# Altium schematic sheet [09] - COMMUNICATION.SchDoc("[09] - COMMUNICATION")
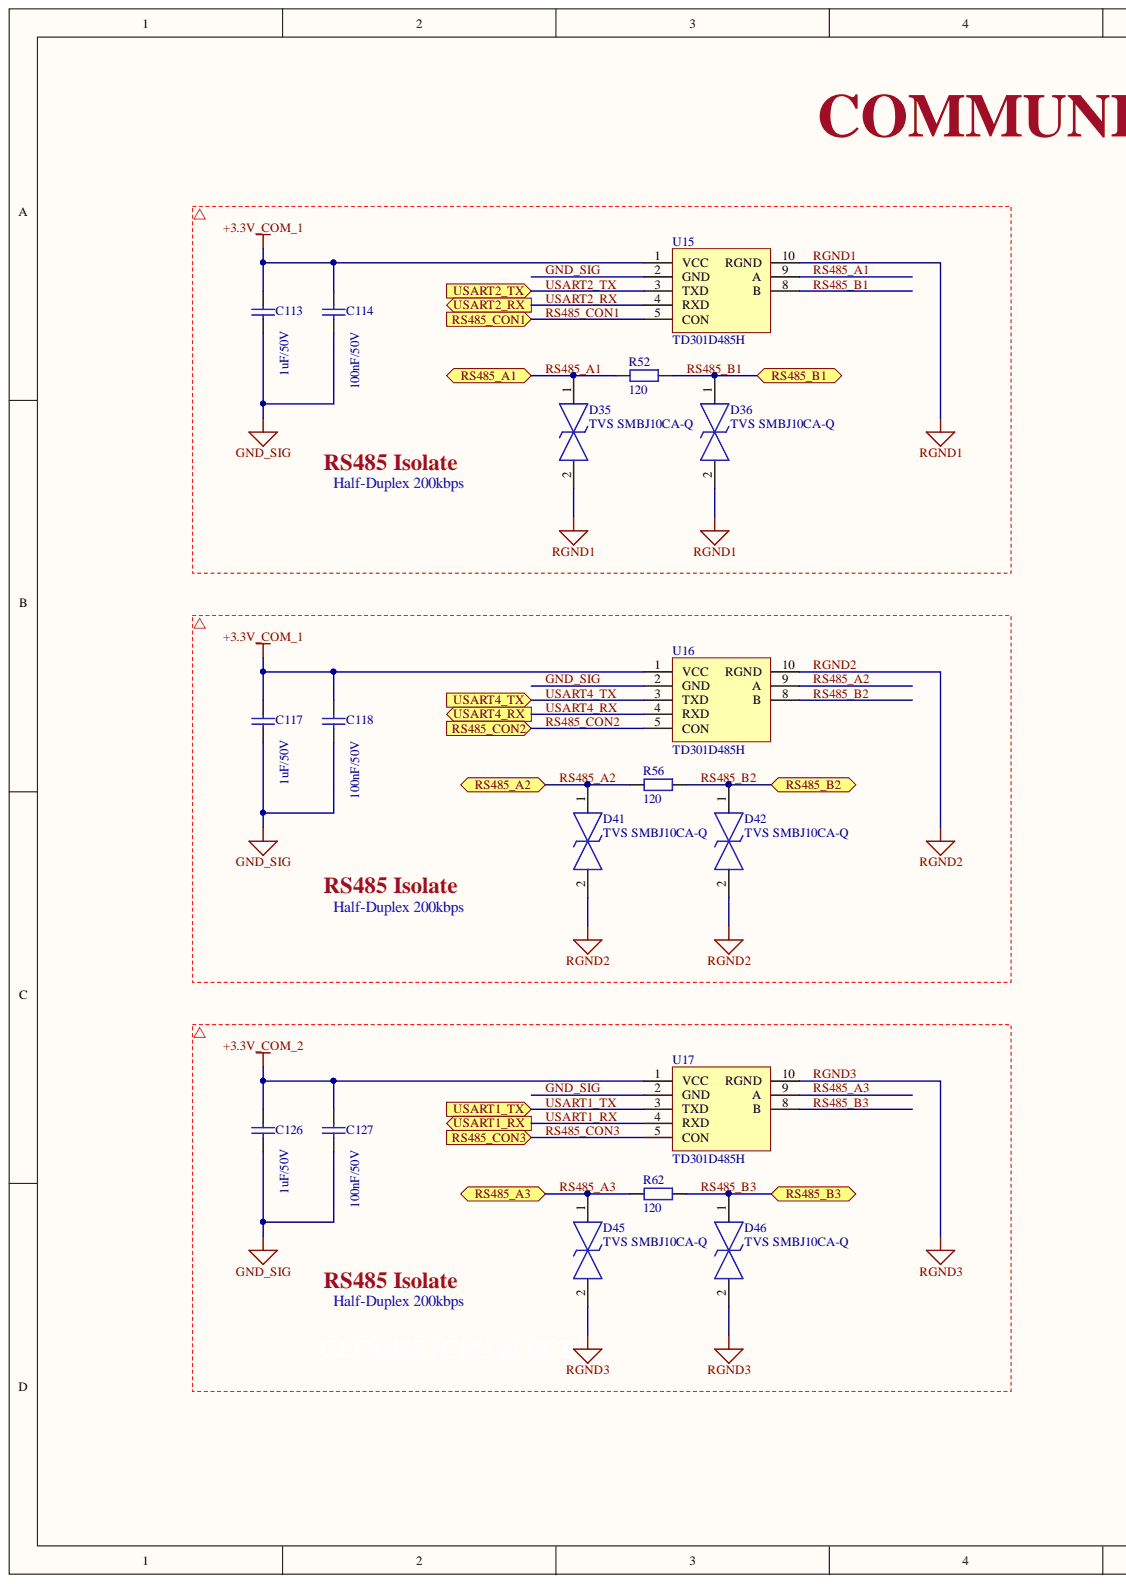
[diagram p0: [09] - COMMUNICATION.SchDoc, left]
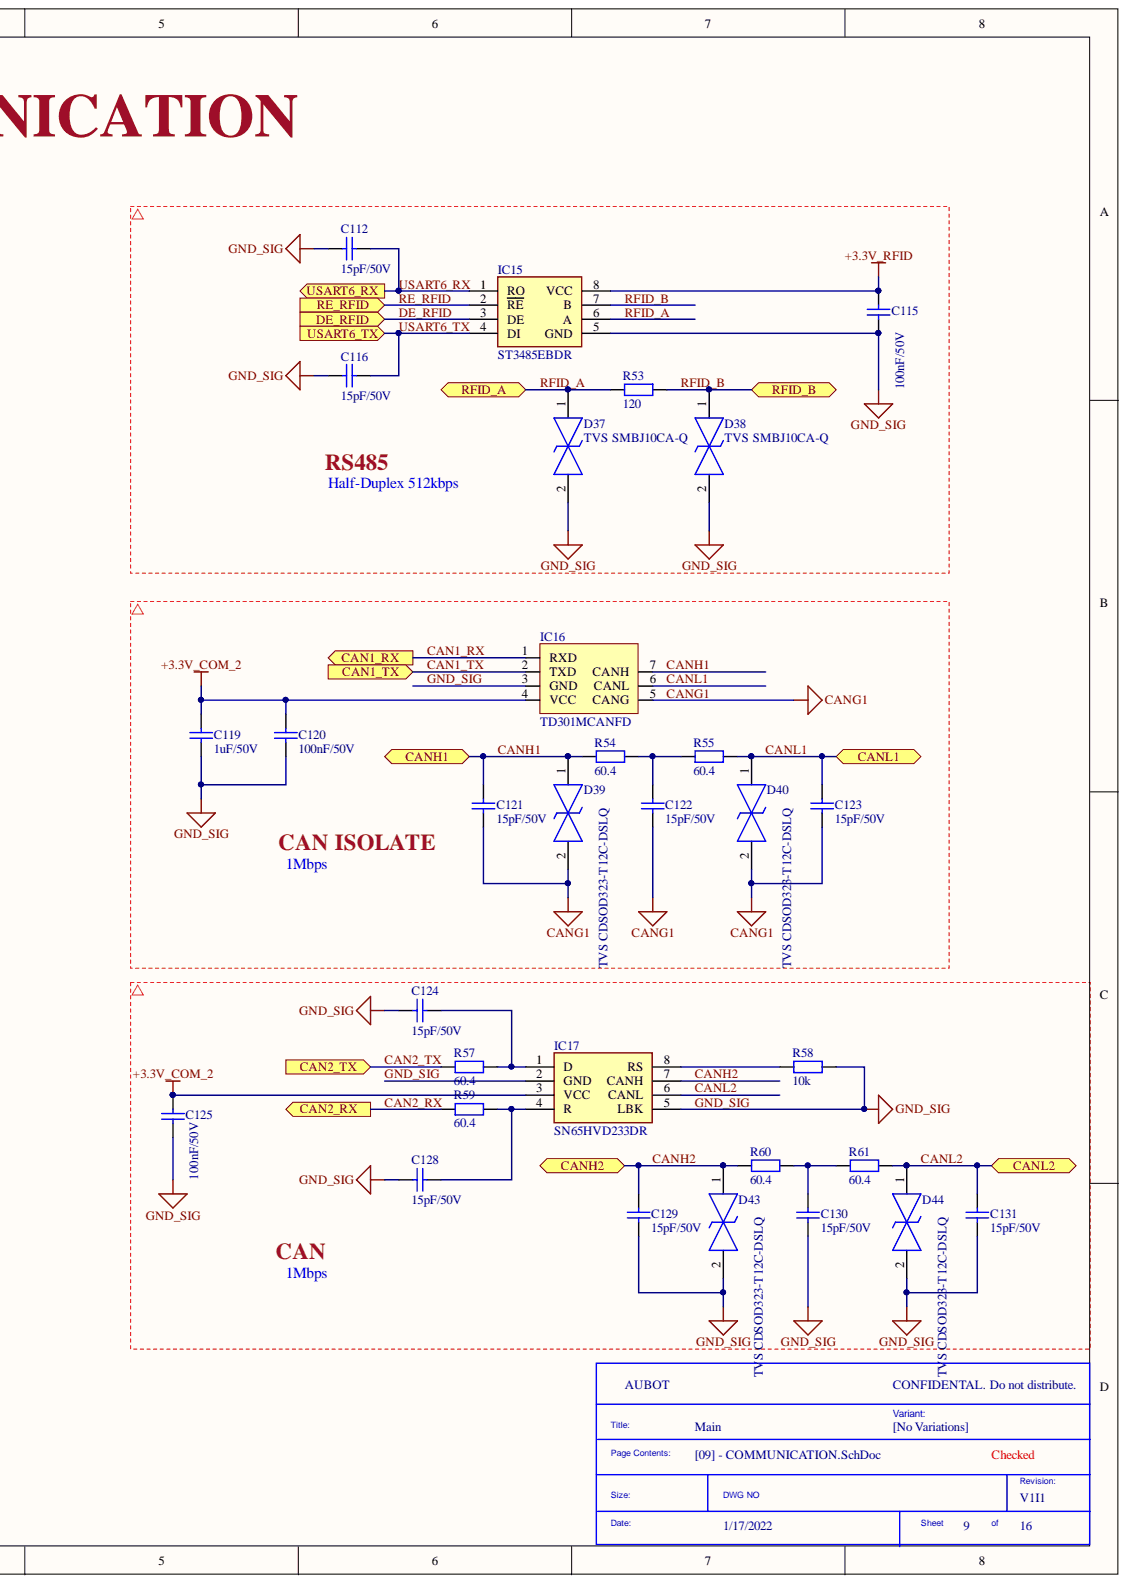
[diagram p1: [09] - COMMUNICATION.SchDoc, right]

## Parts
C112  15pF/50V  [CAP 0805]
C113  1uF/50V  [CAP 0805]
C114  100nF/50V  [CAP 0805]
C115  100nF/50V  [CAP 0805]
C116  15pF/50V  [CAP 0805]
C117  1uF/50V  [CAP 0805]
C118  100nF/50V  [CAP 0805]
C119  1uF/50V  [CAP 0805]
C120  100nF/50V  [CAP 0805]
C121  15pF/50V  [CAP 0805]
C122  15pF/50V  [CAP 0805]
C123  15pF/50V  [CAP 0805]
C124  15pF/50V  [CAP 0805]
C125  100nF/50V  [CAP 0805]
C126  1uF/50V  [CAP 0805]
C127  100nF/50V  [CAP 0805]
C128  15pF/50V  [CAP 0805]
C129  15pF/50V  [CAP 0805]
C130  15pF/50V  [CAP 0805]
C131  15pF/50V  [CAP 0805]
D35  TVS SMBJ10CA-Q  Manufacturer Part Number: SMBJ10CA-Q  [TVS SMBJ]
D36  TVS SMBJ10CA-Q  Manufacturer Part Number: SMBJ10CA-Q  [TVS SMBJ]
D37  TVS SMBJ10CA-Q  Manufacturer Part Number: SMBJ10CA-Q  [TVS SMBJ]
D38  TVS SMBJ10CA-Q  Manufacturer Part Number: SMBJ10CA-Q  [TVS SMBJ]
D39  TVS CDSOD323-T12C-DSLQ  Manufacturer Part Number: CDSOD323-T12C-DSLQ  [TVS SOD-323-2]
D40  TVS CDSOD323-T12C-DSLQ  Manufacturer Part Number: CDSOD323-T12C-DSLQ  [TVS SOD-323-2]
D41  TVS SMBJ10CA-Q  Manufacturer Part Number: SMBJ10CA-Q  [TVS SMBJ]
D42  TVS SMBJ10CA-Q  Manufacturer Part Number: SMBJ10CA-Q  [TVS SMBJ]
D43  TVS CDSOD323-T12C-DSLQ  Manufacturer Part Number: CDSOD323-T12C-DSLQ  [TVS SOD-323-2]
D44  TVS CDSOD323-T12C-DSLQ  Manufacturer Part Number: CDSOD323-T12C-DSLQ  [TVS SOD-323-2]
D45  TVS SMBJ10CA-Q  Manufacturer Part Number: SMBJ10CA-Q  [TVS SMBJ]
D46  TVS SMBJ10CA-Q  Manufacturer Part Number: SMBJ10CA-Q  [TVS SMBJ]
IC15  ST3485EBDR  [SOIC-8]
IC16  TD301MCANFD  [TD301MCANFD]
IC17  SN65HVD233DR  Case/Package: D  [SOIC-8]
R52  120  [RES 0805]
R53  120  [RES 0805]
R54  60.4  [RES 0805]
R55  60.4  [RES 0805]
R56  120  [RES 0805]
R57  60.4  [RES 0805]
R58  10k  [RES 0805]
R59  60.4  [RES 0805]
R60  60.4  [RES 0805]
R61  60.4  [RES 0805]
R62  120  [RES 0805]
U15  TD301D485H  [TD301D485H]
U16  TD301D485H  [TD301D485H]
U17  TD301D485H  [TD301D485H]

## Ports
CAN1_RX, CAN1_TX, CAN2_RX, CAN2_TX, CANH1, CANH2, CANL1, CANL2, DE_RFID, RE_RFID, RFID_A, RFID_B, RS485_A1, RS485_A2, RS485_A3, RS485_B1, RS485_B2, RS485_B3, RS485_CON1, RS485_CON2, RS485_CON3, USART1_RX, USART1_TX, USART2_RX, USART2_TX, USART4_RX, USART4_TX, USART6_RX, USART6_TX

## Nets
+3.3V_COM_1: C113.1 C114.2 C117.1 C118.2 U15.1(VCC) U16.1(VCC)
+3.3V_COM_2: C119.2 C120.1 C125.2 C126.1 C127.2 IC16.4(VCC) IC17.3(VCC) U17.1(VCC)
+3.3V_RFID: C115.1 IC15.8(VCC)
CAN1_RX: IC16.1(RXD) ; port CAN1_RX
CAN1_TX: IC16.2(TXD) ; port CAN1_TX
CAN2_RX: R59.1 ; port CAN2_RX
CAN2_TX: R57.1 ; port CAN2_TX
CANG1: C121.1 C122.1 C123.1 D39.2 D40.2 IC16.5(CANG)
CANH1: C121.2 D39.1 IC16.7(CANH) R54.1 ; port CANH1
CANH2: C129.2 D43.1 IC17.7(CANH) R60.1 ; port CANH2
CANL1: C123.2 D40.1 IC16.6(CANL) R55.2 ; port CANL1
CANL2: C131.2 D44.1 IC17.6(CANL) R61.2 ; port CANL2
DE_RFID: IC15.3(DE) ; port DE_RFID
GND_SIG: C112.1 C113.2 C114.1 C115.2 C116.1 C117.2 C118.1 C119.1 C120.2 C124.1 C125.1 C126.2 C127.1 C128.1 C129.1 C130.1 C131.1 D37.2 D38.2 D43.2 D44.2 IC15.5(GND) IC16.3(GND) IC17.2(GND) IC17.5(LBK) R58.2 U15.2(GND) U16.2(GND) U17.2(GND)
RE_RFID: IC15.2(RE) ; port RE_RFID
RFID_A: D37.1 IC15.6(A) R53.2 ; port RFID_A
RFID_B: D38.1 IC15.7(B) R53.1 ; port RFID_B
RGND1: D35.2 D36.2 U15.10(RGND)
RGND2: D41.2 D42.2 U16.10(RGND)
RGND3: D45.2 D46.2 U17.10(RGND)
RS485_A1: D35.1 R52.2 U15.9(A) ; port RS485_A1
RS485_A2: D41.1 R56.2 U16.9(A) ; port RS485_A2
RS485_A3: D45.1 R62.2 U17.9(A) ; port RS485_A3
RS485_B1: D36.1 R52.1 U15.8(B) ; port RS485_B1
RS485_B2: D42.1 R56.1 U16.8(B) ; port RS485_B2
RS485_B3: D46.1 R62.1 U17.8(B) ; port RS485_B3
RS485_CON1: U15.5(CON) ; port RS485_CON1
RS485_CON2: U16.5(CON) ; port RS485_CON2
RS485_CON3: U17.5(CON) ; port RS485_CON3
USART1_RX: U17.4(RXD) ; port USART1_RX
USART1_TX: U17.3(TXD) ; port USART1_TX
USART2_RX: U15.4(RXD) ; port USART2_RX
USART2_TX: U15.3(TXD) ; port USART2_TX
USART4_RX: U16.4(RXD) ; port USART4_RX
USART4_TX: U16.3(TXD) ; port USART4_TX
USART6_RX: C112.2 IC15.1(RO) ; port USART6_RX
USART6_TX: C116.2 IC15.4(DI) ; port USART6_TX
NetC122_2: C122.2 R54.2 R55.1
NetC124_2: C124.2 IC17.1(D) R57.2
NetC128_2: C128.2 IC17.4(R) R59.2
NetC130_2: C130.2 R60.2 R61.1
NetIC17_8: IC17.8(RS) R58.1

## Library data of the parts
C112 C116 C121-C124 C128-C131 = Cap 0805 15pF/50V: Cap, AEC-Q200, 15PF, 50V, Mlcc, 0805;; Manufacturer Part Number: C0805C150K5RACAUTO; Manufacturer: KEMET; Supplier Part Number 1: 80-C0805C150K5RAUTO; Supplier 1: Mouser; Stock 1: 14030; RoHS Compliant: Yes; RoHS: Yes; Pricing 1: 1 = 0.31000, 10 = 0.20400, 50 = 0.20400, 100 = 0.10400, 1000 = 0.07000, 10000 = 0.06000 (USD); Component Kind: Standard; Library Name: Hieu_Lib.IntLib
C113 C117 C119 C126 = Cap 0805 1uF/50V: 0805 1 uF 50 V ±10 % Tolerance X7R SMT Multilayer Ceramic Capacitor; Manufacturer Part Number: CC0805KKX7R9BB105; Manufacturer: Yageo; Voltage Rating (DC): 50V; Tolerance: 10%; Capacitance: 1uF; Width: 0.049inch; Weight: 0.000194oz; Voltage Rating: 50V; Thickness: 0.057inch; Termination: SMD/SMT; Supplier Part Number 1: 311-1886-1-ND; Supplier 1: Digi-Key; Stock 1: 174238; Series: CC; RoHS Compliant: Yes; RoHS: Yes; Resistor Type: Ceramic; Radiation Hardening: No; Pricing 1: 1 = 0.26000, 10 = 0.17900, 50 = 0.11920, 100 = 0.10130, 500 = 0.07152, 1000 = 0.06257 (USD); Packaging: Tape and Reel; Mount: Surface Mount; Min Operating Temperature: -55°C; Max Operating Temperature: 125°C; Length: 2mm; Lead Free: Lead Free; Height: 1.25mm; Halogen Free: Halogen Free; Dielectric: X7R; Depth: 1.25mm; Construction: Flat; ComponentLink1URL: https://datasheet.ciiva.com/13209/upy-gphc-x7r-6-3v-to-50v-8-12304-13209375.pdf?src-supplier=Mouser; ComponentLink1Description: https://datasheet.ciiva.com/13209/upy-gphc-x7r-6-3v-to-50v-8-12304-13209375.pdf?src-supplier=Mouser; Component Kind: Standard; Case Code (Metric): 2012; Case Code (Imperial): 0805; Case/Package: 0805; Library Name: Hieu_Lib.IntLib
C114 C115 C118 C120 C125 C127 = Cap 0805 100nF/50V: Ceramic capacitor SMD-0805 100nF /50V X7R# K +-10%, Samsung, RoHS; Manufacturer Part Number: CL21B104KBCNNNC; Voltage Rating (DC): 50V; Tolerance: 10%; Dielectric: X7R; Width: 0.049inch; Voltage Rating: 50V; Voltage: 50V; Thickness: 0.037inch; Supplier Part Number 1: C1711; Supplier 1: LCSC; Stock 1: 447650; Series: CL; RoHS Compliant: Yes; RoHS: Yes; Radiation Hardening: No; Pricing 1: 50 = 0.04090, 500 = 0.03130, 1500 = 0.02890, 5000 = 0.02580, 25000 = 0.02430, 50000 = 0.02410, 50 = 0.03950, 500 = 0.03020, 1500 = 0.02790, 5000 = 0.02490, 25000 = 0.02350, 50000 = 0.02330, 50 = 0.20680, 500 = 0.15820, 1500 = 0.14600, 5000 = 0.13020, 25000 = 0.12290, 50000 = 0.12170, 50 = 0.19640, 500 = 0.15020, 1500 = 0.13860, 5000 = 0.12360, 25000 = 0.11670, 50000 = 0.11550, 50 = 0.02640, 500 = 0.02020, 1500 = 0.01860, 5000 = 0.01660, 25000 = 0.01570, 50000 = 0.01550, 50 = 0.02300, 500 = 0.01760, 1500 = 0.01620, 5000 = 0.01440, 25000 = 0.01360, 50000 = 0.01350, 50 = 0.24740, 500 = 0.18920, 1500 = 0.17470, 5000 = 0.15570, 25000 = 0.14700, 50000 = 0.14560, 50 = 3.45220, 500 = 2.64000, 1500 = 2.43700, 5000 = 2.17300, 25000 = 2.05110, 50000 = 2.03080, 50 = 0.13070, 500 = 0.09990, 1500 = 0.09220, 5000 = 0.08230, 25000 = 0.07760, 50000 = 0.07690, 50 = 2.39050, 500 = 1.82810, 1500 = 1.68750, 5000 = 1.50470, 25000 = 1.42030, 50000 = 1.40620, 50 = 0.26740, 500 = 0.20450, 1500 = 0.18870, 5000 = 0.16830, 25000 = 0.15890, 50000 = 0.15730, 50 = 0.04230, 500 = 0.03230, 1500 = 0.02990, 5000 = 0.02660, 25000 = 0.02510, 50000 = 0.02490, 50 = 0.03188, 500 = 0.02438, 1500 = 0.02251, 5000 = 0.02007, 25000 = 0.01894, 50000 = 0.01875 (AUD); Packaging: Tape and Reel; Mount: Surface Mount; Min Operating Temperature: -55°C; Max Operating Temperature: 125°C; Material: Ceramic; Manufacturer: Samsung; Length: 2mm; Lead Free: Lead Free; Height: 0.85mm; Depth: 1.25mm; Contact Plating: Tin; Construction: Flat; ComponentLink2URL: https://datasheet.ciiva.com/29199/454152-da-01-en-vs-kondensator-0805-29199814.pdf?src-supplier=Conrad; ComponentLink2Description: https://datasheet.ciiva.com/29199/454152-da-01-en-vs-kondensator-0805-29199814.pdf?src-supplier=Conrad; ComponentLink1URL: https://datasheet.ciiva.com/25710/cl21b104kbcnnnc-25710182.pdf?src-supplier=Digi-Key; ComponentLink1Description: https://datasheet.ciiva.com/25710/cl21b104kbcnnnc-25710182.pdf?src-supplier=Digi-Key; Component Kind: Standard; Case Code (Metric): 2012; Case Code (Imperial): 0805; Case/Package: 0805; Capacitance: 100nF; Library Name: Hieu_Lib.IntLib
D35-D38 D41 D42 D45 D46 = TVS SMBJ10CA-Q: ESD Suppressors / TVS Diodes DIO TVS VRWM 10V 600W BIDIR SMB AECQ; Manufacturer: Bourns; Supplier Part Number 1: 652-SMBJ10CA-Q; Supplier 1: Mouser; Stock 1: 0; RoHS: No; Pricing 1: 1 = 0.43000, 10 = 0.37200, 50 = 0.37200, 100 = 0.27800, 1000 = 0.16900, 10000 = 0.15300 (USD); Component Kind: Standard; Library Name: Hieu_Lib.SchLib
D39 D40 D43 D44 = TVS CDSOD323-T12C-DSLQ: ESD Suppressor Diode TVS Bi-Dir 12V Automotive 2-Pin SOD-323 T/R; Manufacturer: Bourns; Supplier Part Number 1: 652-CDSOD323T12CDSLQ; Supplier 1: Mouser; Stock 1: 8414; RoHS Compliant: Yes; RoHS: Yes; Pricing 1: 1 = 0.82000, 10 = 0.72600, 50 = 0.72600, 100 = 0.55700, 1000 = 0.35200, 10000 = 0.27900 (USD); ComponentLink1URL: https://www.bourns.com/docs/Product-Datasheets/CDSOD323-T12C-DSLQ.pdf?src-supplier=Digi-Key; ComponentLink1Description: https://www.bourns.com/docs/Product-Datasheets/CDSOD323-T12C-DSLQ.pdf?src-supplier=Digi-Key; Component Kind: Standard; Library Name: Hieu_Lib.IntLib
IC15 = ST3485EBDR: ST3485E Series 3.3 V ±15 kV ESD Protected RS-485/RS-422 Transceiver - SOIC-8; Manufacturer: STMicroelectronics; Operating Supply Voltage: 3.3V; Number of Transmitters: 1; Nominal Supply Current: 2.2mA; Min Supply Voltage: 3V; Max Supply Voltage: 3.6V; Interface: RS-422,RS-485; ESD Protection: Yes; Data Rate: 15Mbps; Width: 4mm; Supplier Part Number 1: 497-2080-6-ND; Supplier 1: Digi-Key; Stock 1: 0; RoHS: Yes; REACH SVHC: No SVHC; Radiation Hardening: No; Pricing 1: 1 = 2.18000, 10 = 1.96200, 25 = 1.85120, 100 = 1.57710, 250 = 1.48080, 500 = 1.29572, 1000 = 1.22238 (USD); Packaging: Tape and Reel; Package Quantity: 1; Number of Transceivers: 1; Number of Receivers: 1; Number of Pins: 8; Number of Drivers: 1; Mount: Surface Mount; Min Operating Temperature: -40°C; Max Supply Current: 2.2mA; Max Operating Temperature: 85°C; Manufacturer Part Number: ST3485EBDR; Logic Function: Transceiver; Length: 5mm; Lead Free: Lead Free; Height: 1.25mm; Contact Plating: Gold; ComponentLink6URL: https://datasheet.ciiva.com/7630/1788494-7630124.pdf?src-supplier=Element14; ComponentLink6Description: https://datasheet.ciiva.com/7630/1788494-7630124.pdf?src-supplier=Element14; ComponentLink5URL: https://datasheet.ciiva.com/7630/1788494-7630107.pdf?src-supplier=Element14; ComponentLink5Description: https://datasheet.ciiva.com/7630/1788494-7630107.pdf?src-supplier=Element14; ComponentLink4URL: https://datasheet.ciiva.com/16209/cd00003137-16209866.pdf?src-supplier=Future+Electronics; ComponentLink4Description: https://datasheet.ciiva.com/16209/cd00003137-16209866.pdf?src-supplier=Future+Electronics; ComponentLink3URL: https://datasheet.ciiva.com/1703/cd00003137-1703123.pdf?src-supplier=Digi-Key; ComponentLink3Description: https://datasheet.ciiva.com/1703/cd00003137-1703123.pdf?src-supplier=Digi-Key; ComponentLink2URL: https://datasheet.ciiva.com/1703/cd00003137-1703122.pdf?src-supplier=Digi-Key; ComponentLink2Description: https://datasheet.ciiva.com/1703/cd00003137-1703122.pdf?src-supplier=Digi-Key; ComponentLink1URL: https://datasheet.ciiva.com/1703/cd00003137-1703121.pdf?src-supplier=Digi-Key; ComponentLink1Description: https://datasheet.ciiva.com/1703/cd00003137-1703121.pdf?src-supplier=Digi-Key; Component Kind: Standard; Case/Package: SOIC; Library Name: Hieu_Lib.IntLib
IC16 = TD301MCANFD: DIP-8 CAN Bus Modules ROHS; Manufacturer: MORNSUN; Supplier Part Number 1: C115915; Supplier 1: LCSC; Manufacturer Part Number: TD301MCANFD; Component Kind: Standard; Library Name: Hieu_Lib.IntLib
IC17 = SN65HVD233DR: 3.3 V CAN Transceiver with Standby Mode, Loopback 8-SOIC -40 to 125; Manufacturer: Texas Instruments; Simplex/Duplex: Half Duplex; Receiver Hysteresis: 100mV; Operating Supply Voltage: 3.3V; Number of Transmit Buffers: 1; Nominal Supply Current: 6mA; Min Supply Voltage: 3V; Max Supply Voltage: 3.6V; Data Rate: 1Mbps; Weight: 0.002561oz; Supplier Part Number 1: 296-35967-1-ND; Supplier 1: Digi-Key; Stock 1: 0; RoHS: Yes; REACH SVHC: No SVHC; Radiation Hardening: No; Pricing 1: 1 = 3.52000, 10 = 3.16400, 25 = 2.99080, 100 = 2.59200, 250 = 2.45908, 500 = 2.20654, 1000 = 2.19450 (USD); Packaging: Tape and Reel; Package Quantity: 2500; Number of Transceivers: 1; Number of Receivers: 1; Number of Receive Buffers: 1; Number of Pins: 8; Number of Drivers: 1; Min Operating Temperature: -40°C; Max Supply Current: 6mA; Max Operating Temperature: 125°C; Manufacturer Part Number: SN65HVD233DR; Lead Free: Lead Free; Contact Plating: Gold; ComponentLink1URL: https://datasheet.ciiva.com/18624/sn65hvd233-18624858.pdf?src-supplier=Digi-Key; ComponentLink1Description: https://datasheet.ciiva.com/18624/sn65hvd233-18624858.pdf?src-supplier=Digi-Key; Component Kind: Standard; Library Name: Hieu_Lib.SchLib
R52 R53 R56 R62 = Res 0805 120 Ohm 1%: Res Thick Film 0805 120 Ohm 1% 0.125W(1/8W) ±100ppm/C Pad SMD T/R; Manufacturer Part Number: RC0805FR-07120RL; Manufacturer: Yageo; Voltage Rating: 150V; Temperature Coefficient: 100ppm/°C; Resistance: 120R; Power Rating: 125mW; Width: 0.049inch; Tolerance: 1%; Termination: SMD/SMT; Technology: THICK FILM; Supplier Part Number 1: 311-120CRCT-ND; Supplier 1: Digi-Key; Stock 1: 149; Size Code: 0805; Series: RC; RoHS Compliant: Yes; RoHS: Yes; Resistor Type: Thick Film; Resistance Tolerance: 1%; REACH SVHC: Unknown; Pricing 1: 1 = 0.10000, 10 = 0.04200, 100 = 0.01690, 1000 = 0.00759, 2500 = 0.00659 (USD); Packaging: Tape and Reel; Package Quantity: 1; Number of Terminations: 2; Min Operating Temperature: -55°C; Military Standard: Not; Max Power Dissipation: 125mW; Max Operating Temperature: 155°C; Length: 2mm; Lead Free: Lead Free; Height: 0.5mm; Features: Moisture Resistant; Depth: 1.25mm; Construction: Rectangular; ComponentLink8URL: https://datasheet.ciiva.com/16964/rc0805-16964606.pdf?src-supplier=Future+Electronics; ComponentLink8Description: https://datasheet.ciiva.com/16964/rc0805-16964606.pdf?src-supplier=Future+Electronics; ComponentLink7URL: https://datasheet.ciiva.com/7941/444164-7941692.pdf?src-supplier=Element14; ComponentLink7Description: https://datasheet.ciiva.com/7941/444164-7941692.pdf?src-supplier=Element14; ComponentLink6URL: https://datasheet.ciiva.com/7941/444164-7941691.pdf?src-supplier=Element14; ComponentLink6Description: https://datasheet.ciiva.com/7941/444164-7941691.pdf?src-supplier=Element14; ComponentLink5URL: https://datasheet.ciiva.com/12558/rc0805-257173-12558661.pdf?src-supplier=Mouser; ComponentLink5Description: https://datasheet.ciiva.com/12558/rc0805-257173-12558661.pdf?src-supplier=Mouser; ComponentLink4URL: https://datasheet.ciiva.com/2491/pyu-r-int-thick-7-2491587.pdf?src-supplier=Digi-Key; ComponentLink4Description: https://datasheet.ciiva.com/2491/pyu-r-int-thick-7-2491587.pdf?src-supplier=Digi-Key; ComponentLink3URL: https://datasheet.ciiva.com/2491/pyu-r-int-thick-7-2491586.pdf?src-supplier=Digi-Key; ComponentLink3Description: https://datasheet.ciiva.com/2491/pyu-r-int-thick-7-2491586.pdf?src-supplier=Digi-Key; ComponentLink2URL: https://datasheet.ciiva.com/2491/pyu-r-int-thick-7-2491585.pdf?src-supplier=Digi-Key; ComponentLink2Description: https://datasheet.ciiva.com/2491/pyu-r-int-thick-7-2491585.pdf?src-supplier=Digi-Key; ComponentLink1URL: https://datasheet.ciiva.com/11819/0900766b8106beab-11819786.pdf?src-supplier=RSComponents; ComponentLink1Description: https://datasheet.ciiva.com/11819/0900766b8106beab-11819786.pdf?src-supplier=RSComponents; Component Kind: Standard; Case Code (Metric): 2012; Case Code (Imperial): 0805; Case/Package: 0805; Library Name: Hieu_Lib.IntLib
R54 R55 R57 R59-R61 = Res 0805 60.4 Ohm 1%: Res Thick Film 0805 60.4 Ohm 1% 0.125W(1/8W) ±100ppm/C Pad SMD T/R; Manufacturer Part Number: RC0805FR-0760R4L; Manufacturer: Yageo; Working Voltage: 150V; Temperature Coefficient: 100ppm/°C; Resistance: 60.4R; Power Rating: 125mW; Width: 0.049inch; Voltage Rating (DC): 150V; Voltage Rating: 150V; Tolerance: 1%; Termination: SMD/SMT; Technology: THICK FILM; Supplier Part Number 1: 311-60.4CRCT-ND; Supplier 1: Digi-Key; Stock 1: 27050; Series: RC; RoHS Compliant: Yes; RoHS: Yes; Resistor Type: Thick Film; Radiation Hardening: No; Pricing 1: 1 = 0.10000, 10 = 0.04200, 100 = 0.01690, 1000 = 0.00759, 2500 = 0.00659 (USD); Packaging: Tape and Reel; Package Quantity: 1; Number of Terminations: 2; Min Operating Temperature: -55°C; Military Standard: Not; Max Power Dissipation: 125mW; Max Operating Temperature: 155°C; Length: 0.079inch; Lead Free: Lead Free; Height: 0.024inch; Features: Moisture Resistant; Depth: 1.25mm; Contact Plating: Tin,Matte,Lead; Construction: Rectangular; ComponentLink3URL: https://datasheet.ciiva.com/2457/pyu-r-int-thick-7-2457215.pdf?src-supplier=Digi-Key; ComponentLink3Description: https://datasheet.ciiva.com/2457/pyu-r-int-thick-7-2457215.pdf?src-supplier=Digi-Key; ComponentLink2URL: https://datasheet.ciiva.com/2457/pyu-r-int-thick-7-2457217.pdf?src-supplier=Digi-Key; ComponentLink2Description: https://datasheet.ciiva.com/2457/pyu-r-int-thick-7-2457217.pdf?src-supplier=Digi-Key; ComponentLink1URL: https://datasheet.ciiva.com/2457/pyu-r-int-thick-7-2457216.pdf?src-supplier=Digi-Key; ComponentLink1Description: https://datasheet.ciiva.com/2457/pyu-r-int-thick-7-2457216.pdf?src-supplier=Digi-Key; Component Kind: Standard; Case Code (Metric): 2012; Case Code (Imperial): 0805; Case/Package: 0805; Library Name: Hieu_Lib.IntLib
R58 = Res 0805 10k Ohm 1%: Res SMD 0805 10K 1% 0.125W T.C.100PPM; Manufacturer Part Number: RC0805FR-0710KL; Manufacturer: Yageo; Voltage Rating: 150V; Temperature Coefficient: 100ppm/°C; Resistance: 10kR; Power Rating: 125mW; Width: 0.049inch; Tolerance: 1%; Termination: SMD/SMT; Technology: THICK FILM; Supplier Part Number 1: 311-10.0KCRCT-ND; Supplier 1: Digi-Key; Stock 1: 4587552; Size Code: 0805; Series: RC; RoHS Compliant: Yes; RoHS: Yes; Resistor Type: Thick Film; Resistance Tolerance: 1%; REACH SVHC: Unknown; Pricing 1: 1 = 0.10000, 10 = 0.04200, 100 = 0.01690, 1000 = 0.00759, 2500 = 0.00659 (USD); Packaging: Tape and Reel; Package Quantity: 1; Number of Terminations: 2; Min Operating Temperature: -55°C; Military Standard: Not; Max Power Dissipation: 125mW; Max Operating Temperature: 155°C; Length: 2mm; Lead Free: Lead Free; Height: 0.024inch; Features: Moisture Resistant; Depth: 1.25mm; Construction: Rectangular; Component Kind: Standard; Case Code (Metric): 2012; Case Code (Imperial): 0805; Case/Package: 0805; Library Name: Hieu_Lib.IntLib
U15-U17 = TD301D485H: DIP RS485 Bus Modules ROHS; Manufacturer: MORNSUN; Supplier Part Number 1: C183992; Supplier 1: LCSC; Pricing: 1 = 3.003; Manufacturer Part Number: TD301D485H; Component Kind: Standard; Library Name: Hieu_Lib.SchLib
